# Altium schematic sheet jtag.SchDoc(jtag)
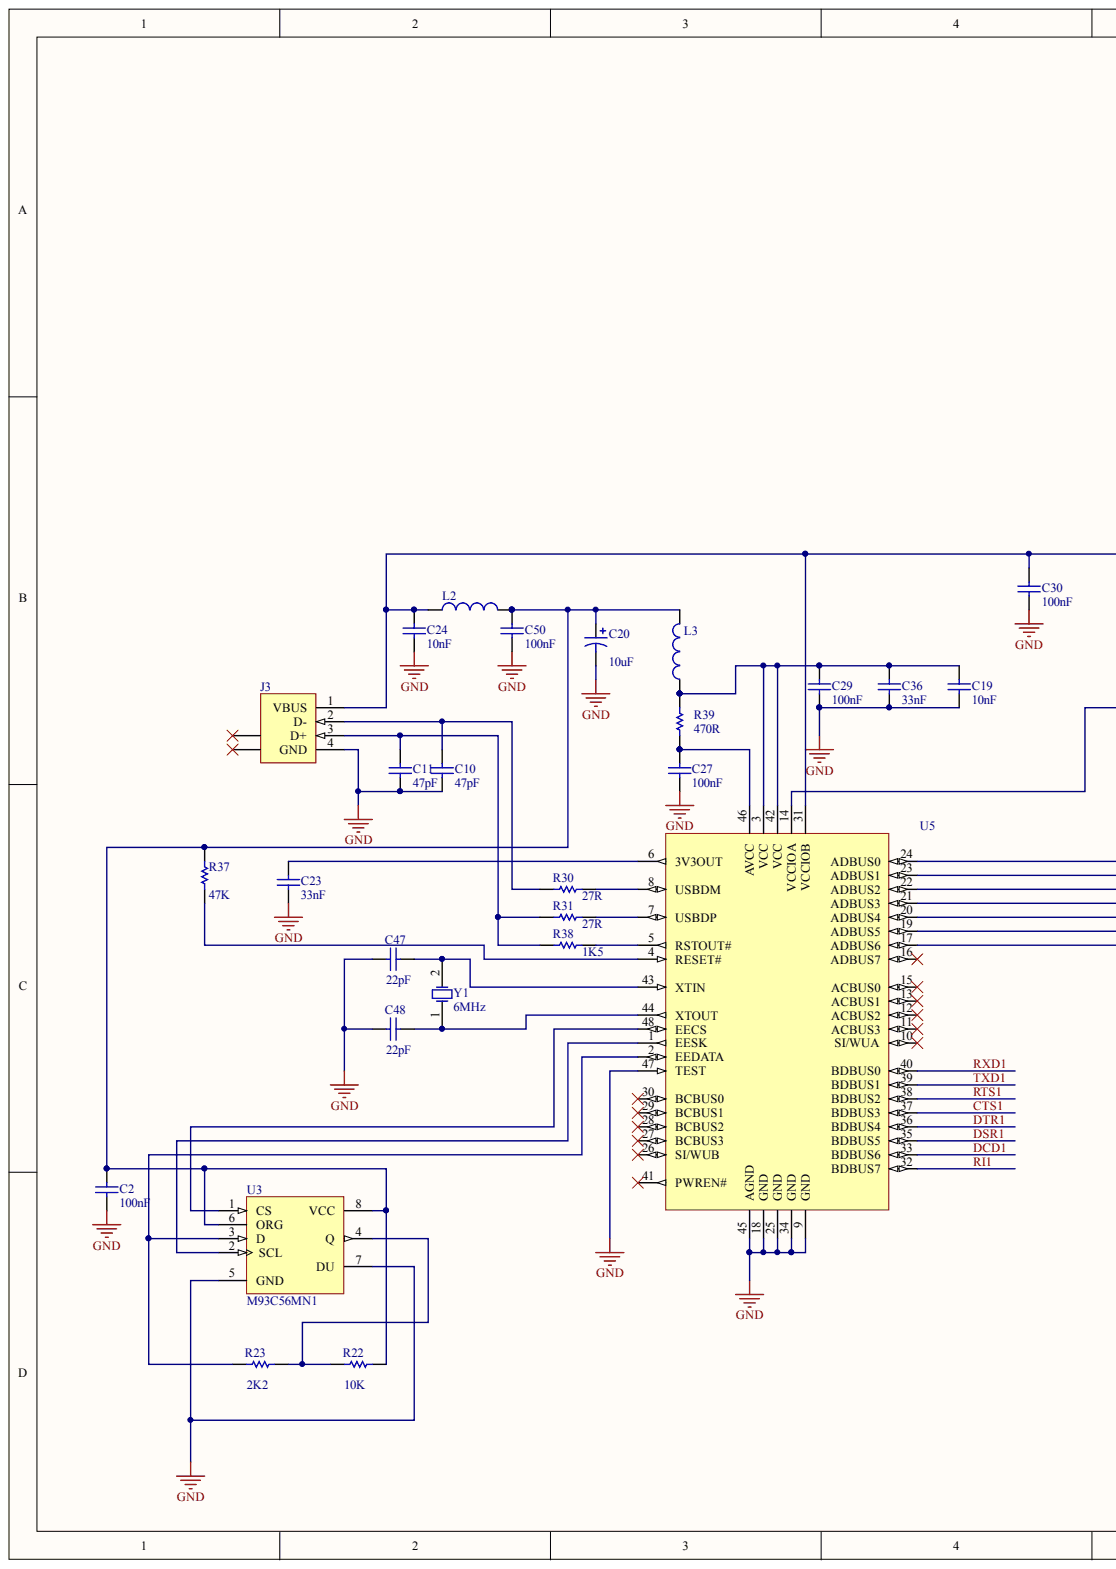
[diagram p0: jtag.SchDoc, left]
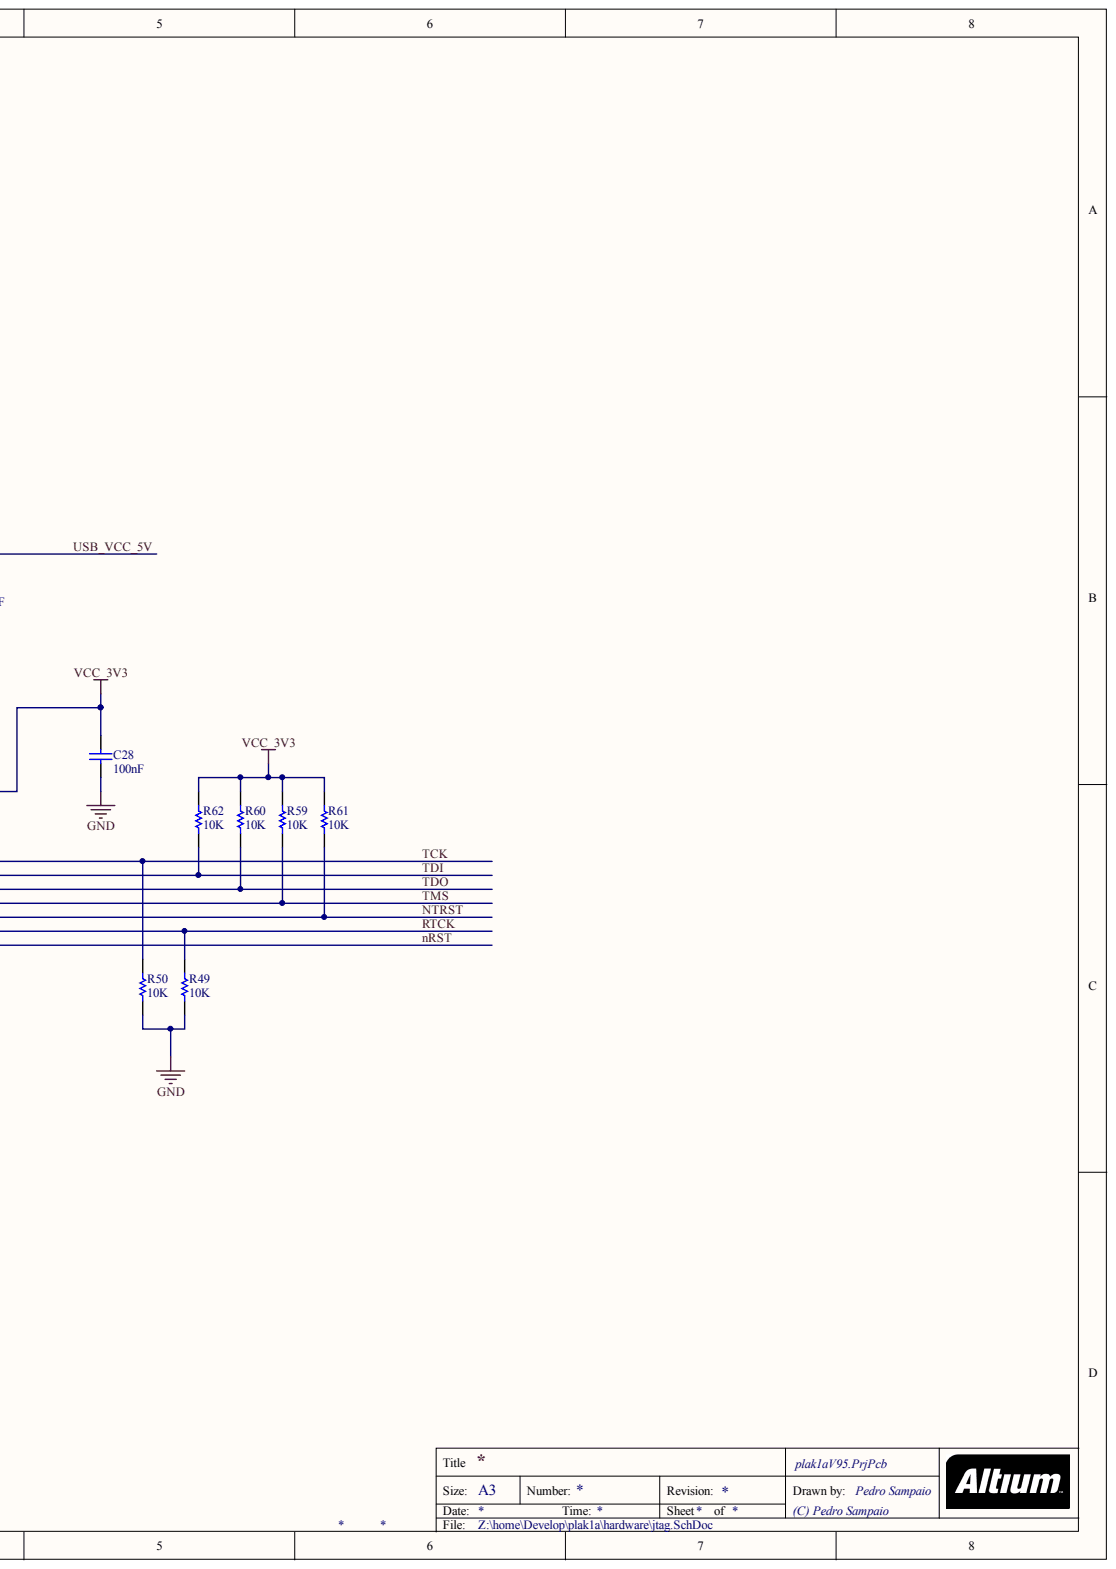
[diagram p1: jtag.SchDoc, right]

## Parts
C2  value 100nF  [1608[0603]]  (hidden comment: 1301716)
C10  value 47pF  [2012[0805]]  (hidden comment: 9406263)
C11  value 47pF  [2012[0805]]  (hidden comment: 9406263)
C19  value 10nF  [1608[0603]]  (hidden comment: 1327671)
C20  value 10uF  [B]  (hidden comment: T491B)
C23  value 33nF  [1608[0603]]  (hidden comment: 5212868)
C24  value 10nF  [1608[0603]]  (hidden comment: 1327671)
C27  value 100nF  [1608[0603]]  (hidden comment: 1301716)
C28  value 100nF  [1608[0603]]  (hidden comment: 1301716)
C29  value 100nF  [1608[0603]]  (hidden comment: 1301716)
C30  value 100nF  [1608[0603]]  (hidden comment: 1301716)
C36  value 33nF  [1608[0603]]  (hidden comment: 5212868)
C47  value 22pF  [2012[0805]]  (hidden comment: 9406263)
C48  value 22pF  [2012[0805]]  (hidden comment: 9406263)
C50  value 100nF  [1608[0603]]  (hidden comment: 1301716)
J3  1097897  [440119]  (value not drawn)
L2  1308766  [L0603]  (value not drawn)
L3  1308766  [L0603]  (value not drawn)
R22  value 10K  [3216[1206]]  (hidden comment: 9240640)
R23  value 2K2  [3216[1206]]  (hidden comment: 9240560)
R30  value 27R  [3216[1206]]  (hidden comment: 9240330)
R31  value 27R  [3216[1206]]  (hidden comment: 9240330)
R37  value 47K  [3216[1206]]  (hidden comment: 9240721)
R38  value 1K5  [3216[1206]]  (hidden comment: 9240543)
R39  value 470R  [3216[1206]]  (hidden comment: 9240489)
R49  value 10K  [3216[1206]]  (hidden comment: Res Semi)
R50  value 10K  [3216[1206]]  (hidden comment: Res Semi)
R59  value 10K  [3216[1206]]  (hidden comment: Res Semi)
R60  value 10K  [3216[1206]]  (hidden comment: Res Semi)
R61  value 10K  [3216[1206]]  (hidden comment: Res Semi)
R62  value 10K  [3216[1206]]  (hidden comment: Res Semi)
U3  M93C56MN1  [SOP8_M]
U5  FT2232C  [LQFP-48_N]  (value not drawn)
Y1  6MHz  [HC49/4H_SMX]

## Nets
GND: C2.1 C10.1 C11.1 C19.1 C20.2 C23.1 C24.1 C27.1 C28.1 C29.1 C30.1 C36.1 C47.1 C48.1 C50.1 J3.4(GND) R49.1 R50.1 U3.5(GND) U3.7(DU) U5.9(GND) U5.18(GND) U5.25(GND) U5.34(GND) U5.45(AGND) U5.47(TEST)
VCC_3V3: C28.2 R59.2 R60.2 R61.2 R62.2 U5.14(VCCIOA)
CTS1: U5.37(BDBUS3)
DCD1: U5.33(BDBUS6)
DSR1: U5.35(BDBUS5)
DTR1: U5.36(BDBUS4)
NRST: U5.17(ADBUS6)
NTRST: R61.1 U5.20(ADBUS4)
RI1: U5.32(BDBUS7)
RTCK: R49.2 U5.19(ADBUS5)
RTS1: U5.38(BDBUS2)
RXD1: U5.40(BDBUS0)
TCK: R50.2 U5.24(ADBUS0)
TDI: R62.1 U5.23(ADBUS1)
TDO: R60.1 U5.22(ADBUS2)
TMS: R59.1 U5.21(ADBUS3)
TXD1: U5.39(BDBUS1)
USB_VCC_5V: C24.2 C30.2 J3.1(VBUS) L2.1 U5.31(VCCIOB)
NetC2_2: C2.2 C20.1 C50.2 L2.2 L3.2 R22.2 R37.2 U3.6(ORG) U3.8(VCC)
NetC10_2: C10.2 J3.2(D-) R30.1
NetC11_2: C11.2 J3.3(D+) R31.1 R38.1
NetC19_2: C19.2 C29.2 C36.2 L3.1 R39.2 U5.3(VCC) U5.42(VCC)
NetC23_2: C23.2 U5.6(3V3OUT)
NetC27_2: C27.2 R39.1 U5.46(AVCC)
NetC47_2: C47.2 U5.43(XTIN) Y1.2
NetC48_2: C48.2 U5.44(XTOUT) Y1.1
NetR22_1: R22.1 R23.2 U3.4(Q)
NetR23_1: R23.1 U3.3(D) U5.2(EEDATA)
NetR30_2: R30.2 U5.8(USBDM)
NetR31_2: R31.2 U5.7(USBDP)
NetR37_1: R37.1 U5.4(RESET#)
NetR38_2: R38.2 U5.5(RSTOUT#)
NetU3_1: U3.1(CS) U5.48(EECS)
NetU3_2: U3.2(SCL) U5.1(EESK)

Not connected: J3 5 6; U5 10(SI/WUA) 11(ACBUS3) 12(ACBUS2) 13(ACBUS1) 15(ACBUS0) 16(ADBUS7) 26(SI/WUB) 27(BCBUS3) 28(BCBUS2) 29(BCBUS1) 30(BCBUS0) 41(PWREN#)

## Library data of the parts
C2 C19 C23 C24 C27-C30 C36 C50 = C0603: Ceramic Chip Capacitor - Standard; Note: Footprint corresponds to dimensions for bulk cassette packaging. This case size has tightened tolerances.; Code_IPC: 1608 [0603]; Code_JEITA: 0603; Component Kind: Standard; ComponentLink1Description: Manufacturer Link; ComponentLink1URL: http://www.kemet.com/; ComponentLink2Description: Datasheet; ComponentLink2URL: http://www.kemet.com/kemet/web/homepage/kechome.nsf/vapubfilesname/F3102TA.pdf/$file/F3102TA.pdf; DatasheetDocument: Mar-2001; LatestRevisionDate: 19-Mar-2004; LatestRevisionNote: Silkscreen size reduced.; Library Name: KEMET Chip Capacitor.IntLib; PackageDocument: Mar-2001; PackageReference: 0603; Published: 17-Jul-2002; Signal Integrity: C0603; Simulation: CAP; Publisher: Altium Limited
  C2 C27-C30 C50: Farnell: 1301716
  C19 C24: Farnell: 1288254
  C23 C36: Farnell: 5212868
C10 C11 C47 C48 = C0805: Ceramic Chip Capacitor - Standard; Note: Footprint corresponds to dimensions for bulk cassette packaging. This case size has tightened tolerances.; Code_IPC: 2012[0805]; Code_JEITA: 0805; Component Kind: Standard; ComponentLink1Description: Manufacturer Link; ComponentLink1URL: http://www.kemet.com/; ComponentLink2Description: Datasheet; ComponentLink2URL: http://www.kemet.com/kemet/web/homepage/kechome.nsf/vapubfilesname/F3102TA.pdf/$file/F3102TA.pdf; DatasheetDocument: Mar-2001; Farnell: 9406263; LatestRevisionDate: 19-Mar-2004; LatestRevisionNote: Silkscreen size reduced.; Library Name: KEMET Chip Capacitor.IntLib; PackageDocument: Mar-2001; PackageReference: 0805; Published: 17-Jul-2002; Signal Integrity: C0805; Simulation: CAP; Publisher: Altium Limited
C20 = T491B: Solid Tantalum Chip Capacitor, Standard T491 Series - Industrial Grade; Code_JEITA: 3528-21; Component Kind: Standard; ComponentLink1Description: Manufacturer Link; ComponentLink1URL: http://www.kemet.com/; ComponentLink2Description: Datasheet; ComponentLink2URL: http://www.kemet.com/kemet/web/homepage/kechome.nsf/vapubfilesname/F3102TA.pdf/$file/F3102TA.pdf; DatasheetDocument: Mar-2001; Farnell: 1301818; LatestRevisionDate: 19-Mar-2004; LatestRevisionNote: Silkscreen dimensions re-sized.; Library Name: KEMET Chip Capacitor.IntLib; PackageDocument: Mar-2001; PackageReference: B; Published: 17-Jul-2002; Signal Integrity: T491B; Simulation: CAP; Publisher: Altium Limited
J3 = 440119-1: USB 1.1, Right Angle, Thru-Hole, B Type, Receptacle, 4 Position, Beige; ContactMaterial: Copper Alloy; ContactPlating: Gold Flash over Nickel; DrillSize: Corresponds to nominal value.; PanelGround: Without; PCB_Layout: Complies with manufacturer's recommendation.; TerminationLength: 2.84mm [0.112in.]; Component Kind: Standard; ComponentLink1Description: Manufacturer Link; ComponentLink1URL: http://www.tycoelectronics.com/; ComponentLink2Description: Packaging Specification; ComponentLink2URL: http://ecommas.tycoelectronics.com/commerce/DocumentDelivery/DDEController?Action=showdoc&DocId=Customer+Drawing%7F440119%7FC%7Ftif%7FEnglish%7FC_440119_C.tif; DatasheetDocument: 12-Jan-2004; Farnell: 1097897; HousingMaterial: Thermoplastic; Library Name: AMP Serial Bus USB.IntLib; PackageDocument: 12-Jan-2004; PackageReference: 440119; Published: 15-Jul-2004; Signal Integrity: USB1.1; Publisher: Altium Limited
L2 L3 = Inductor: Code_IEC: 1005; Value: 10mH; Code_JEITA: 0402; Component Kind: Standard; Description: Inductor; Farnell: 1308766; LatestRevisionDate: 17-Jul-2002; LatestRevisionNote: Re-released for DXP Platform.; Library Name: Miscellaneous Devices.IntLib; PackageReference: 0402-A; Published: 8-Jun-2000; Signal Integrity: Inductor; Simulation: INDUCTOR; Publisher: Altium Limited
R22 R23 R30 R31 R37-R39 R49 R50 R59-R62 = Res Semi: Semiconductor Resistor; Component Kind: Standard; LatestRevisionDate: 17-Jul-2002; LatestRevisionNote: Re-released for DXP Platform.; Library Name: Miscellaneous Devices.IntLib; PackageReference: AXIAL-0.5; Published: 8-Jun-2000; Signal Integrity: Res Semi; Simulation: RES; Publisher: Altium Limited
  R22 R49 R50 R59-R62: Farnell: 9240640
  R23: Farnell: 9240560
  R30 R31: Farnell: 9240330
  R37: Farnell: 9240721
  R38: Farnell: 9240543
  R39: Farnell: 9240489
U3 = M93C56MN1: 2Kb (x8/x16) Serial Microwire Bus EEPROM; Note: Do Not Use pin number 7 (DU).; Component Kind: Standard; ComponentLink1Description: Manufacturer Link; ComponentLink1URL: http://www.st.com/; ComponentLink2Description: Datasheet; ComponentLink2URL: http://www.st.com/stonline/products/literature/ds/4997/m93c46.pdf; DatasheetDocument: Feb-1999; Farnell: 9882448; LatestRevisionDate: 6-Jun-2005; LatestRevisionNote: Stylized 3D Model Added.; Library Name: ST Memory EEPROM Serial.IntLib; PackageDocument: Jul-1999; PackageReference: SOP8; PCB3D: SOP8; Published: 24-Aug-2001; Publisher: Altium Limited
U5 = FT2232C: Dual USB UART/FIFO Chip, LQFP-48; Code_IPC: SQFP7X7-48; Component Kind: Standard; ComponentLink1Description: Manufacturer Link; ComponentLink1URL: http://www.ftdichip.com/Products/FT2232C.htm; ComponentLink2Description: Datasheet; ComponentLink2URL: http://www.ftdichip.com/Documents/DataSheets/ds2232c_15.pdf; DatasheetDocument: ver 1.4, May-2005; Farnell: 9519793; Library Name: FTDI Communication UART.IntLib; PackageDocument: May-2005; PackageReference: LQFP-48; Published: 6-Oct-2005; Publisher: Altium Limited
Y1 = HC49/4H_SMX: Surface Mount Quartz Crystal; Note: PCB layout complies with manufacturer's recommendation.; Component Kind: Standard; ComponentLink1Description: Manufacturer Link; ComponentLink1URL: http://www.cmac.com/mt/; ComponentLink2Description: Datasheet; ComponentLink2URL: http://www.cmac.com/mt/databook/crystals/smd/hc49_4h_smx.pdf; DatasheetDocument: 18-Oct-1999; Farnell: 9713310; Library Name: C-MAC Crystal Oscillator.IntLib; PackageDocument: 18-Oct-1999; PackageReference: HC49/4H_SMX; Published: 17-Jul-2002; Publisher: Altium Limited
